# Altium schematic sheet Undero_Timing_pod.SchDoc(Undero_Timing_pod)
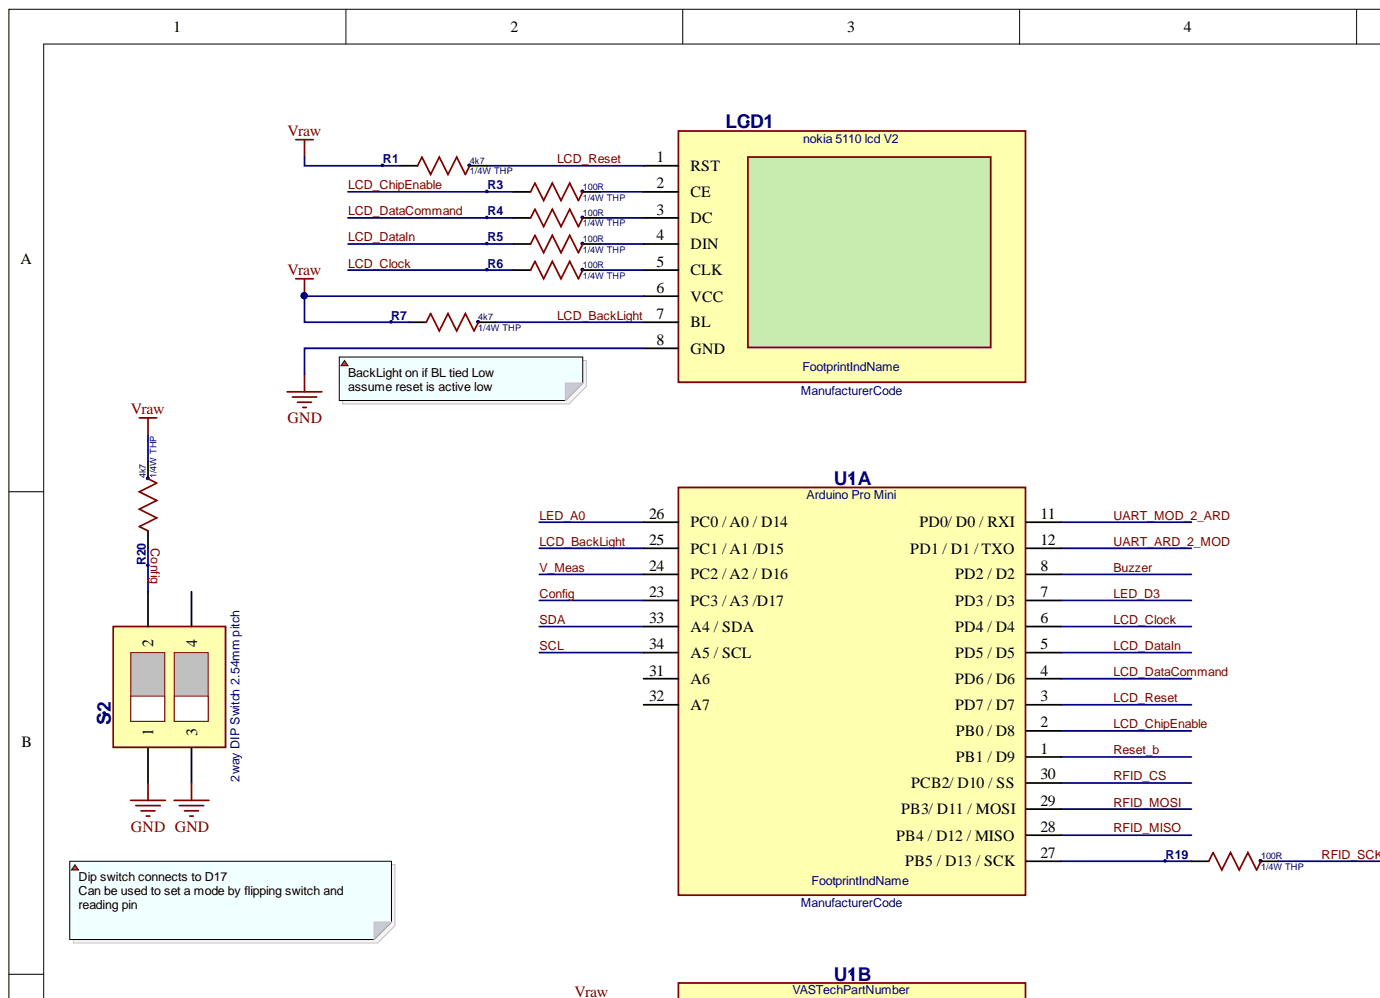
[diagram p0: Undero_Timing_pod.SchDoc, top left]
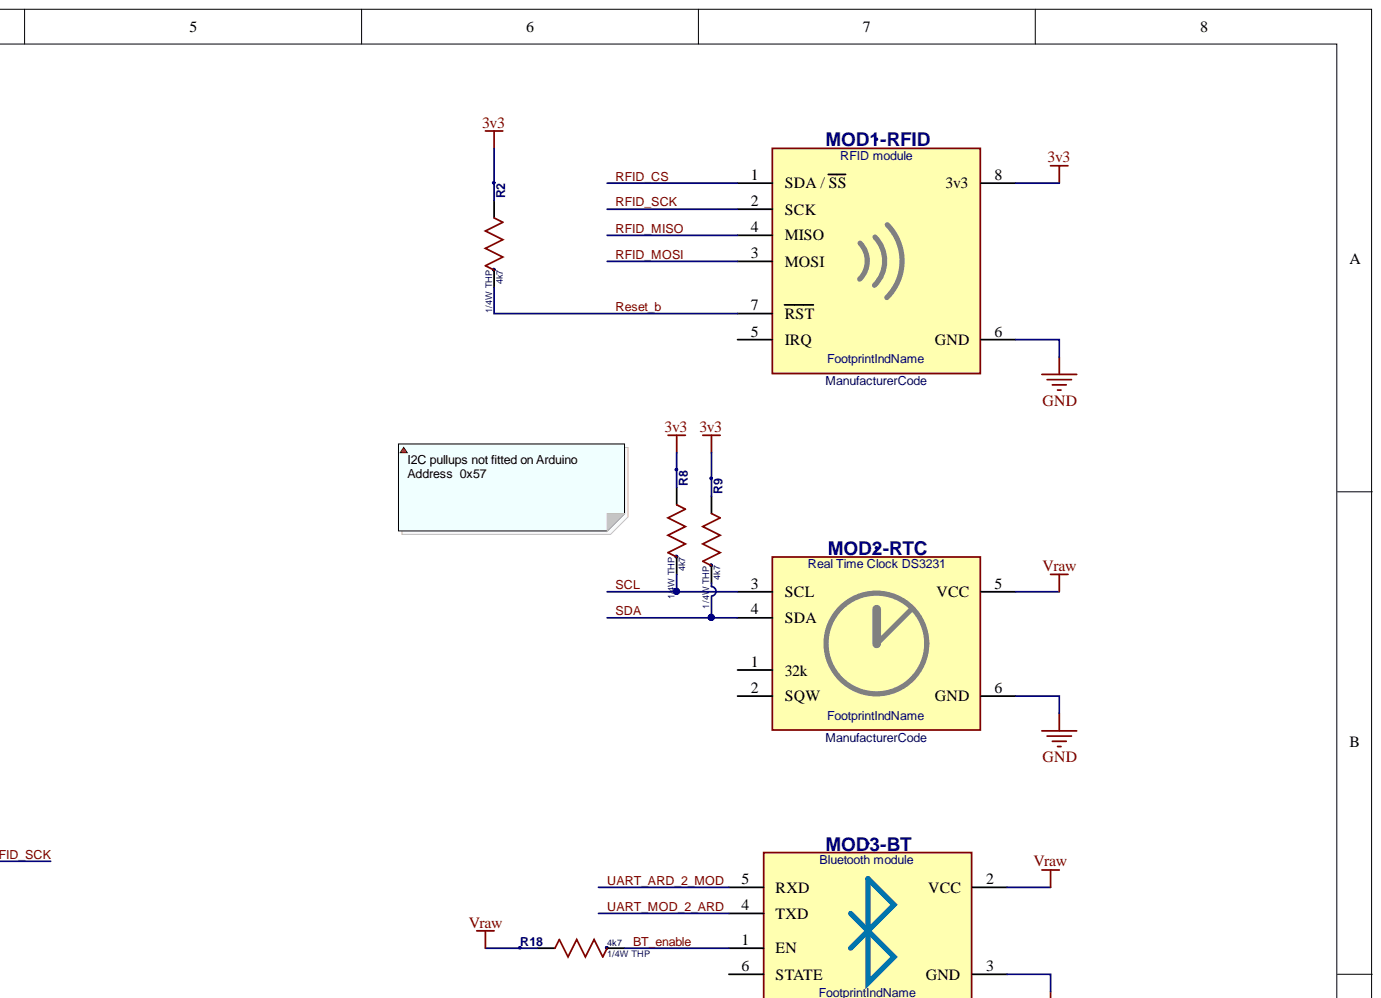
[diagram p1: Undero_Timing_pod.SchDoc, top right]
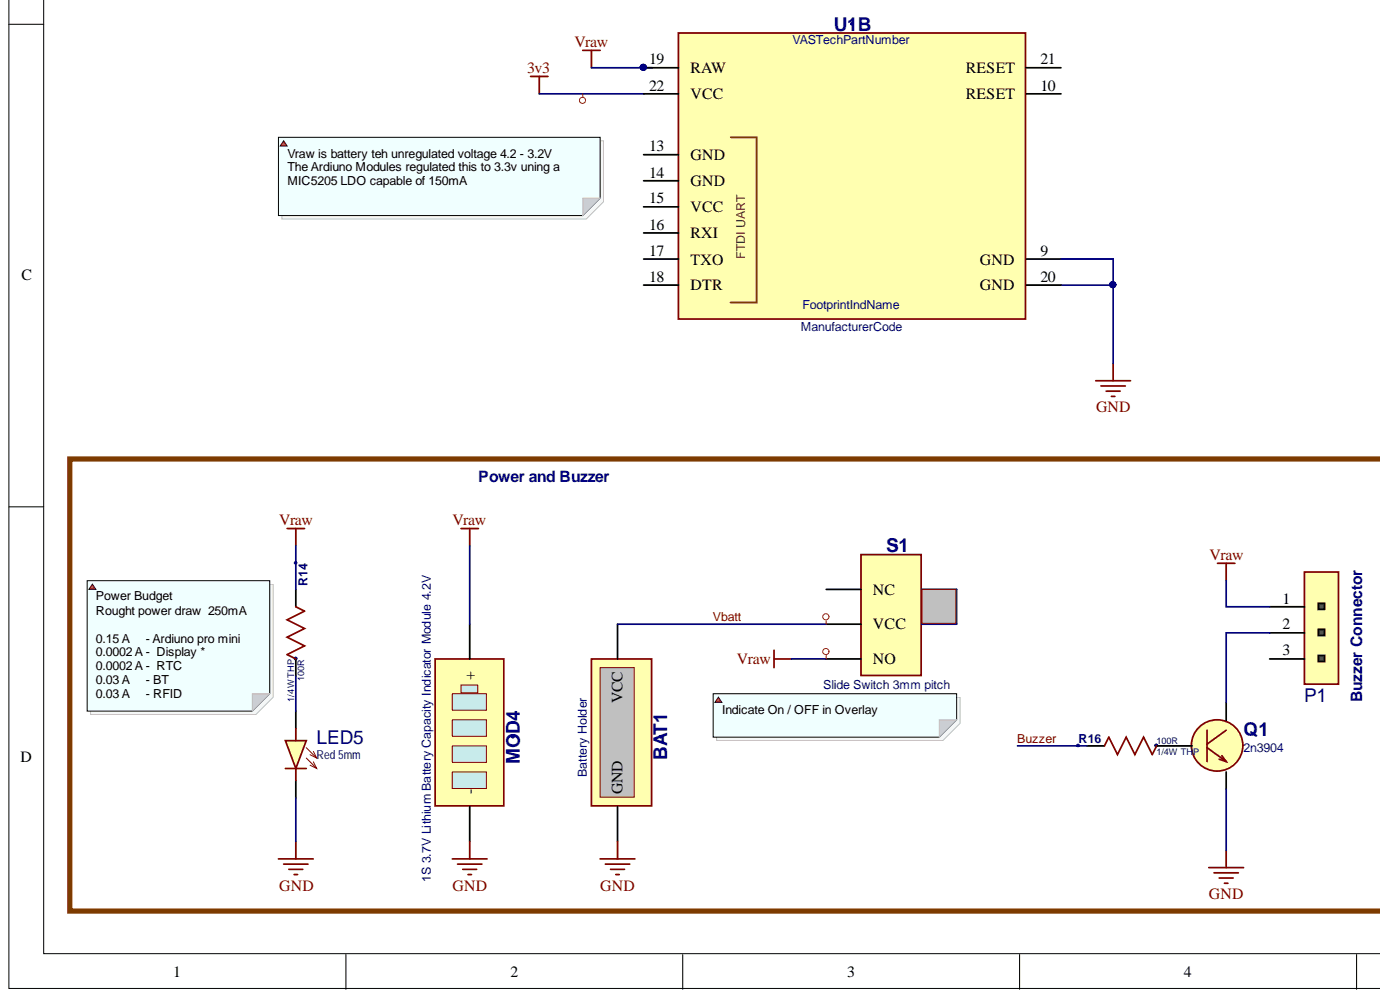
[diagram p2: Undero_Timing_pod.SchDoc, bottom left]
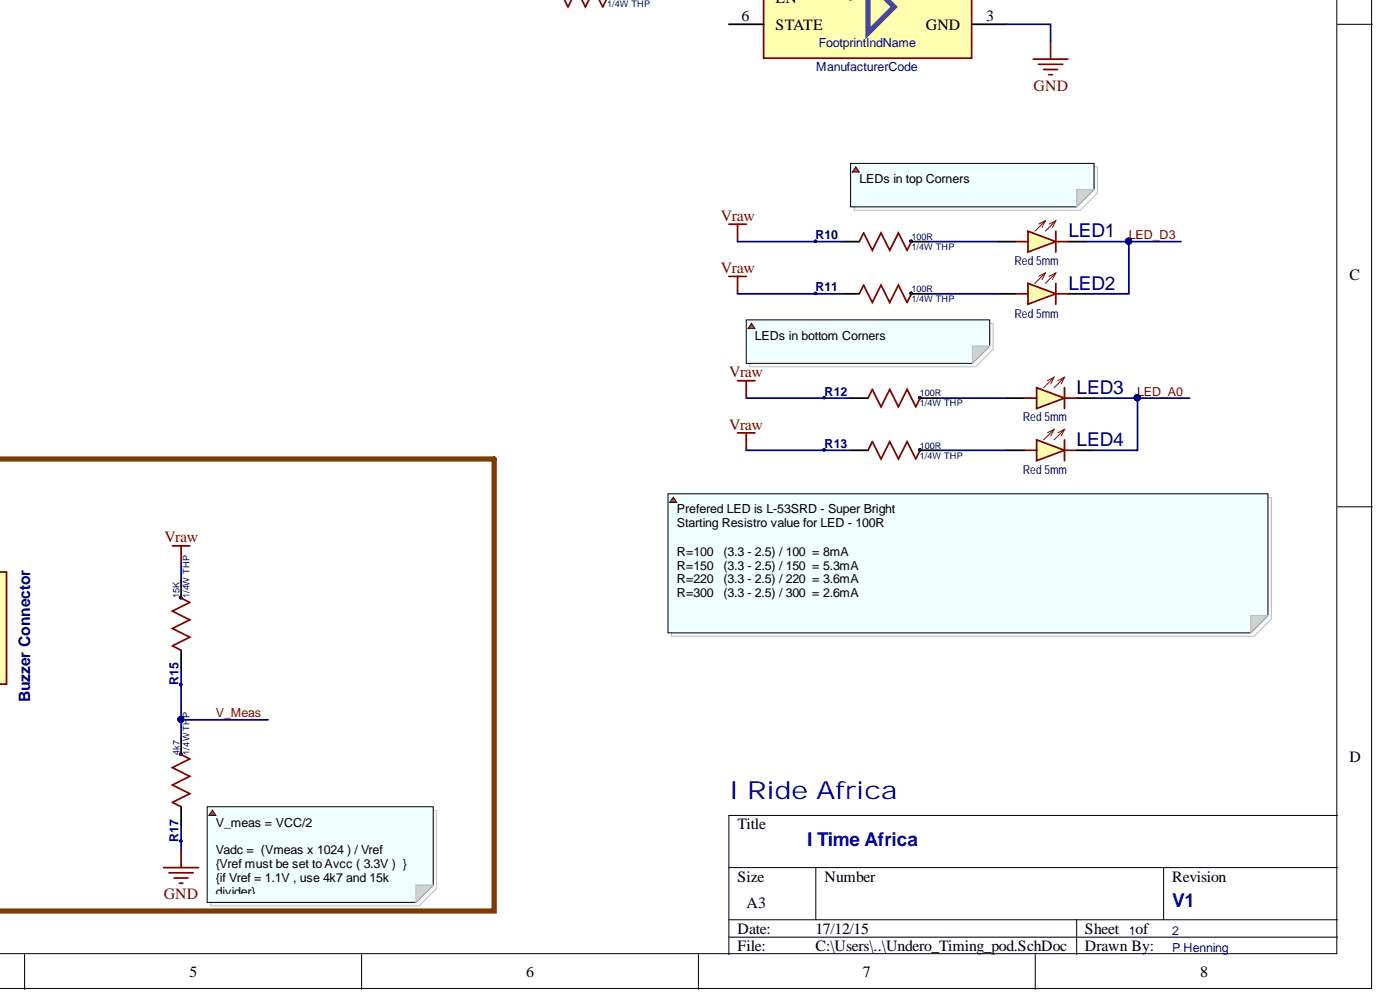
[diagram p3: Undero_Timing_pod.SchDoc, bottom right]

## Parts
BAT1  Battery Holder  [Battery Holder]
LCD1  nokia 5110 lcd V2  Attributes: nokia 5110 lcd  [Nokia 5110 GLCD 84x48]
LED1  Red 5mm  [LED THL 5x9mm Vert]
LED2  Red 5mm  [LED THL 5x9mm Vert]
LED3  Red 5mm  [LED THL 5x9mm Vert]
LED4  Red 5mm  [LED THL 5x9mm Vert]
LED5  Red 5mm  [LED THL 5x9mm Vert]
MOD1-RFID  SymbolAttribute  [RFID-RC522]  (value not drawn)
MOD2-RTC  SymbolAttribute  [RTC DS3231]  (value not drawn)
MOD3-BT  SymbolAttribute  [BlueTooth Card]  (value not drawn)
MOD4  1S 3.7V Lithium Battery Capacity Indicator Module 4.2V  [Battery Indicator]
P1  3 pin Screw Terminal 3.5mm  [3x1 Screw terminal 3.5mm]  (value not drawn)
Q1  2n3904  [TO-92]
R1  4k7  Package: 1/4W THP  [Res 1/4W THP]
R2  4k7  Package: 1/4W THP  [Res 1/4W THP]
R3  100R  Package: 1/4W THP  [Res 1/4W THP]
R4  100R  Package: 1/4W THP  [Res 1/4W THP]
R5  100R  Package: 1/4W THP  [Res 1/4W THP]
R6  100R  Package: 1/4W THP  [Res 1/4W THP]
R7  4k7  Package: 1/4W THP  [Res 1/4W THP]
R8  4k7  Package: 1/4W THP  [Res 1/4W THP]
R9  4k7  Package: 1/4W THP  [Res 1/4W THP]
R10  100R  Package: 1/4W THP  [Res 1/4W THP]
R11  100R  Package: 1/4W THP  [Res 1/4W THP]
R12  100R  Package: 1/4W THP  [Res 1/4W THP]
R13  100R  Package: 1/4W THP  [Res 1/4W THP]
R14  100R  Package: 1/4W THP  [Res 1/4W THP]
R15  15K  Package: 1/4W THP  [Res 1/4W THP]
R16  100R  Package: 1/4W THP  [Res 1/4W THP]
R17  4k7  Package: 1/4W THP  [Res 1/4W THP]
R18  4k7  Package: 1/4W THP  [Res 1/4W THP]
R19  100R  Package: 1/4W THP  [Res 1/4W THP]
R20  4k7  Package: 1/4W THP  [Res 1/4W THP]
S1  SagePartNumber  [Slide Switch 3mm]  (value not drawn)
S2  SagePartNumber  [2way DIP switch]  (value not drawn)
U1  Arduino Pro Mini  [ARDUINO PRO MINI (NO FTDI)]  (parts A,B)

## Nets
3v3: MOD1-RFID.8(3v3) R2.1 R8.1 R9.1 U1.22(VCC)
GND: BAT1.2 LCD1.8(GND) LED5.2 MOD1-RFID.6(GND) MOD2-RTC.6(GND) MOD3-BT.3(GND) MOD4.2 Q1.3 R17.1 S2.1 S2.3 U1.9(GND) U1.20(GND)
Vraw: LCD1.6(VCC) MOD2-RTC.5(VCC) MOD3-BT.2(VCC) MOD4.1 P1.1 R1.1 R7.1 R10.1 R11.1 R12.1 R13.1 R14.1 R15.2 R18.1 R20.2 S1.3 U1.19(RAW)
BT_enable: MOD3-BT.1(EN) R18.2
Buzzer: R16.1 U1.8(PD2_/_D2)
Config: R20.1 S2.2 U1.23(PC3_/_A3_/D17)
LCD_BackLight: LCD1.7(BL) R7.2 U1.25(PC1_/_A1_/D15)
LCD_ChipEnable: R3.1 U1.2(PB0_/_D8)
LCD_Clock: R6.1 U1.6(PD4_/_D4)
LCD_DataCommand: R4.1 U1.4(PD6_/_D6)
LCD_DataIn: R5.1 U1.5(PD5_/_D5)
LCD_Reset: LCD1.1(RST) R1.2 U1.3(PD7_/_D7)
LED_A0: LED3.2 LED4.2 U1.26(PC0_/_A0_/_D14)
LED_D3: LED1.2 LED2.2 U1.7(PD3_/_D3)
Reset_b: MOD1-RFID.7(RST) R2.2 U1.1(PB1_/_D9)
RFID_CS: MOD1-RFID.1(SDA_/_SS) U1.30(PCB2/_D10_/_SS)
RFID_MISO: MOD1-RFID.4(MISO) U1.28(PB4_/_D12_/_MISO)
RFID_MOSI: MOD1-RFID.3(MOSI) U1.29(PB3/_D11_/_MOSI)
RFID_SCK: MOD1-RFID.2(SCK) R19.2
SCL: MOD2-RTC.3(SCL) R8.2 U1.34(A5_/_SCL)
SDA: MOD2-RTC.4(SDA) R9.2 U1.33(A4_/_SDA)
UART_ARD_2_MOD: MOD3-BT.5(RXD) U1.12(PD1_/_D1_/_TXO)
UART_MOD_2_ARD: MOD3-BT.4(TXD) U1.11(PD0/_D0_/_RXI)
V_Meas: R15.1 R17.2 U1.24(PC2_/_A2_/_D16)
Vbatt: BAT1.1 S1.2
NetLCD1_2: LCD1.2(CE) R3.2
NetLCD1_3: LCD1.3(DC) R4.2
NetLCD1_4: LCD1.4(DIN) R5.2
NetLCD1_5: LCD1.5(CLK) R6.2
NetLED1_1: LED1.1 R10.2
NetLED2_1: LED2.1 R11.2
NetLED3_1: LED3.1 R12.2
NetLED4_1: LED4.1 R13.2
NetLED5_1: LED5.1 R14.2
NetP1_2: P1.2 Q1.1
NetQ1_2: Q1.2 R16.2
NetR19_1: R19.1 U1.27(PB5_/_D13_/_SCK)

Not connected: MOD1-RFID 5(IRQ); MOD2-RTC 1(32k) 2(SQW); MOD3-BT 6(STATE); P1 3; S1 1; S2 4; U1 10(RESET) 13(GND) 14(GND) 15(VCC) 16(RXI) 17(TXO) 18(DTR) 21(RESET) 31(A6) 32(A7)

## Library data of the parts
LCD1 = nokia 5110 lcd V2: ManufacturerPartNumber: unknown; Supplier_1: AlliExpress; Package: Package; Manufacturer: unknown; Description: nokia 5110 lcd V2; Component Kind: Standard; Library Name: Undero_Symbols_v2.SchLib
LED1-LED5 = Red LED THP: Red LED, 5mm THP; ManufacturerPartNumber: L-53SR; Manufacturer: kingbright; Supplier_1: http://www.communica.co.za/Catalog/Details/P2732841061; Package: 5mm x9; Component Kind: Standard; Attributes: Red 5mm; Library Name: Copy of Undero_Symbols_v7.SchLib
MOD1-RFID = RFID module: ManufacturerPartNumber: RFID-RC533; Supplier_1: AlliExpress; Package: Package; Description: RFID module; Component Kind: Standard; Attributes: RFID module; Library Name: Undero_Symbols_v6.SchLib
MOD2-RTC = Real Time Clock DS3231: Supplier_1: AlliExpress; Package: Package; ManufacturerPartNumber: ?; Description: Real Time Clock DS3231; Component Kind: Standard; Attributes: Real Time Clock DS3231; Library Name: Undero_Symbols_v6.SchLib
MOD3-BT = Bluetooth module: Supplier_1: AlliExpress; Package: Package; ManufacturerPartNumber: ?; Description: Bluetooth module; Component Kind: Standard; Attributes: Bluetooth module; Library Name: Undero_Symbols_v6.SchLib
MOD4 = Battery Indicator: Supplier_2: ?; Supplier_1: AlliExpress; Package: 45mm x 20mm; Manufacturer: ?; Description: 1S 3.7V Lithium Battery Capacity Indicator Module 4.2V; Component Kind: Standard; Attributes: 1S 3.7V Lithium Battery Capacity Indicator Module 4.2V; Library Name: Undero_Symbols_v6.SchLib
P1 = 3 pin Screw Terminal 3.5mm: ManufacturerPartNumber: 1984950; Manufacturer: RS; Supplier_1: https://www.netram.co.za/2955-screw-terminal-35mm-2-pin-5-pk.html; Package: 3x1 3.5mm; Description: 3 pin Screw Terminal 3.5mm; Component Kind: Standard; Attributes: 3 pin Screw Terminal 3.5mm; Library Name: Undero_Symbols_v6.SchLib
Q1 = NPN Transistor: Manufacturer: Fairchild; Supplier_1: http://www.communica.co.za/Catalog/Details/P0173638625; Package: TO92; ManufacturerPartNumber: 2n3904; Description: NPN Transistor; Component Kind: Standard; Attributes: 2n3904; Library Name: Undero_Symbols_v6.SchLib
R1 R2 R7-R9 R17 R18 R20 = 4k7: Resistor; Supplier_1: comunica; Component Kind: Standard; Attributes: 4k7; Library Name: Undero_Symbols_v6.SchLib
R3-R6 R10-R14 R16 R19 = 100R: Resistor; Supplier_1: comunica; Component Kind: Standard; Attributes: 100R; Library Name: Undero_Symbols_v6.SchLib
R15 = 15K: Resistor; Supplier_1: comunica; Component Kind: Standard; Attributes: 15K; Library Name: Undero_Symbols_v6.SchLib
S1 = Slide Switch 3mm pitch: Manufacturer: Arcolectric Corporation; Supplier_2: http://www.communica.co.za/catalog/Details/P2624616678; Supplier_1: http://www.communica.co.za/Catalog/Details/P2077750286; Package: 3x1 3mm; Description: Slide Switch 3mm pitch; Component Kind: Standard; Attributes: Slide Switch 3mm pitch; Library Name: Undero_Symbols_v6.SchLib
S2 = 2way DIP Switch 2.54mm pitch: ManufacturerPartNumber: KTS02E; Manufacturer: Arcolectric Corporation; Attributes: 2x DIP Switch 2.54mm pitch; Supplier_2: https://za.rs-online.com/web/p/dip-sip-switches/8772116/; Supplier_1: http://www.communica.co.za/Catalog/Details/P1597622190; Package: 2x2 2.54; Description: 2way DIP Switch 2.54mm pitch; Component Kind: Standard; Library Name: Copy of Undero_Symbols_v7.SchLib
U1 = Arduino Pro Mini: ManufacturerPartNumber: DEV-11113  (check this); Manufacturer: SparkFun Electronics; Supplier_1: AlliExpress; Package: Package; Description: Arduino Pro Mini; Component Kind: Standard; Attributes: Arduino Pro Mini; Library Name: Undero_Symbols_v6.SchLib
BAT1 = Battery Holder: Supplier_2: ?; Supplier_1: AlliExpress; Package: Package; Manufacturer: ?; Description: Battery Holder; Component Kind: Standard; Attributes: Battery Holder; Library Name: Undero_Symbols_v6.SchLib
